# Altium schematic sheet ReboostV2_USB.SchDoc
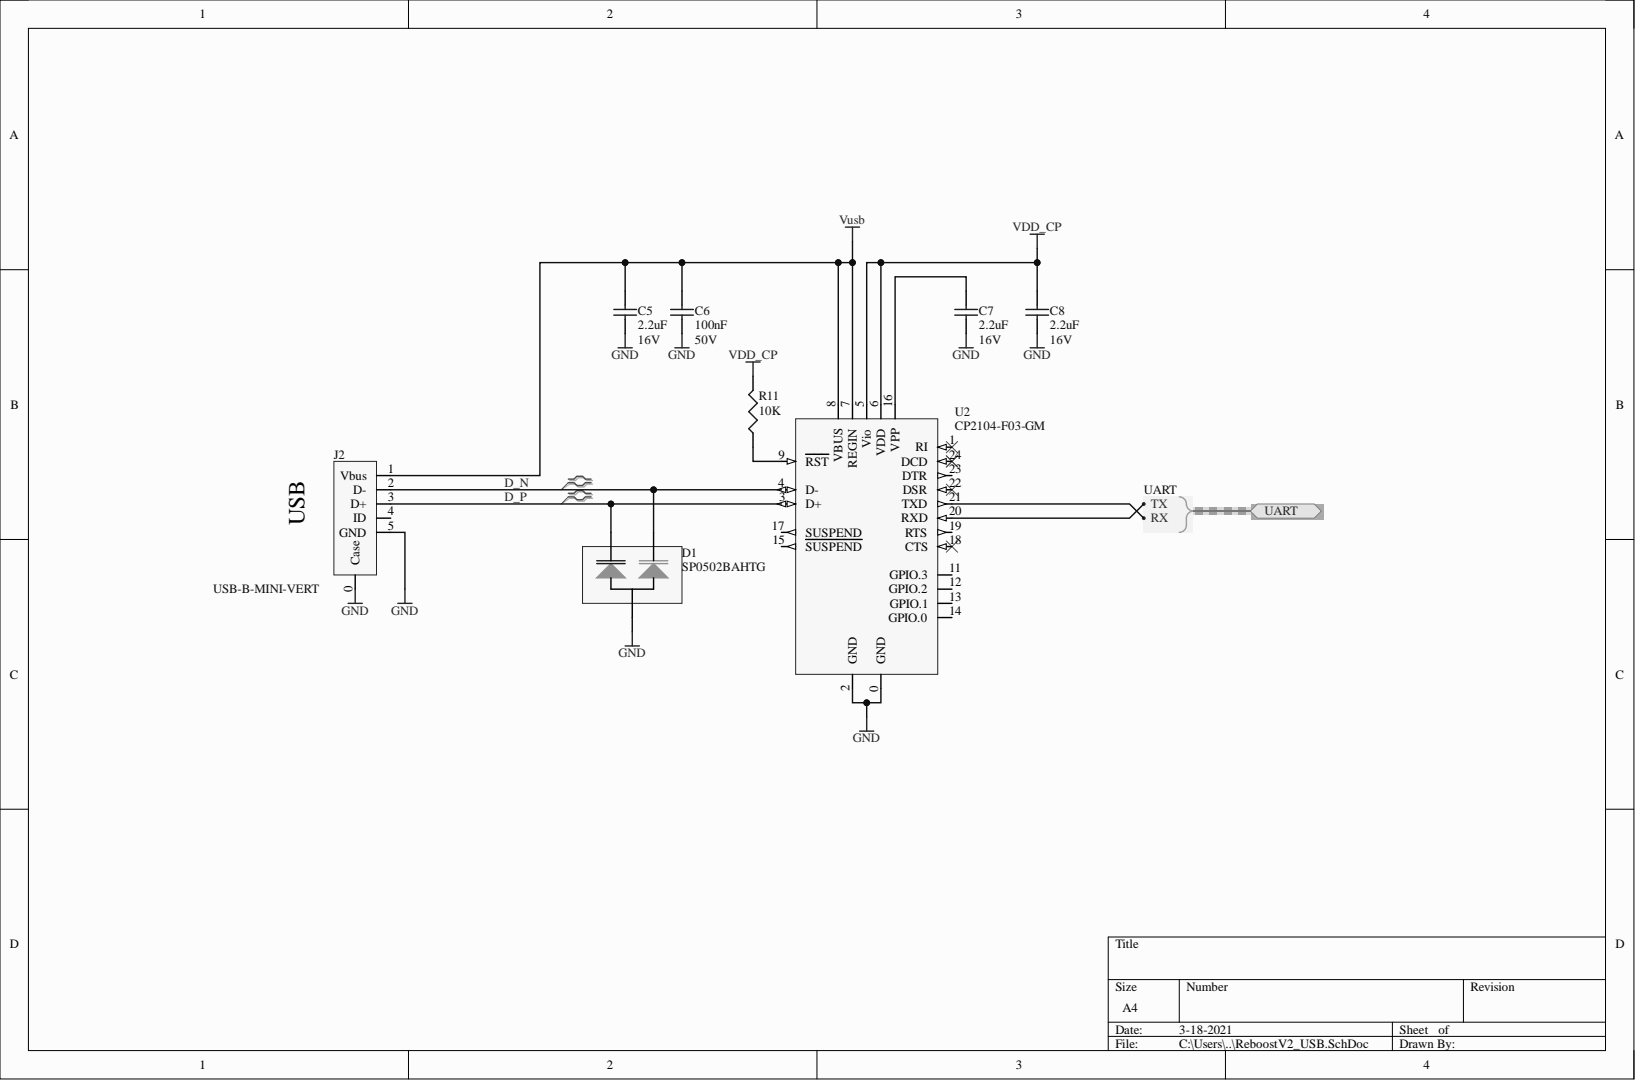
[diagram p0: ReboostV2_USB.SchDoc]

## Parts
C5  2.2uF  Voltage Rating: 16V  [C0603]
C6  100nF  Voltage Rating: 50V  [C0603]
C7  2.2uF  Voltage Rating: 16V  [C0603]
C8  2.2uF  Voltage Rating: 16V  [C0603]
D1  SP0502BAHTG  [SOT23]
J2  USB-B-MINI-VERT  [USB-B-MINI-VERT]
R11  10K  [0603]
U2  CP2104-F03-GM  [QFN24]

## Ports
UART, UART.RX, UART.TX

## Nets
GND: C5.1 C6.1 C7.1 C8.1 D1.A J2.0(Case) J2.5(GND) U2.0(GND) U2.2(GND)
VDD_CP: C8.2 R11.2 U2.5(Vio) U2.6(VDD)
Vusb: C5.2 C6.2 J2.1(Vbus) U2.7(REGIN) U2.8(VBUS)
D_N: D1.K1 J2.2(D-) U2.4(D-)
D_P: D1.K2 J2.3(D+) U2.3(D+)
NetC7_2: C7.2 U2.16(VPP)
NetJ2_4: J2.4(ID)
NetR11_1: R11.1 U2.9(RST)
NetU2_1: U2.1(RI)
NetU2_11: U2.11(GPIO.3)
NetU2_12: U2.12(GPIO.2)
NetU2_13: U2.13(GPIO.1)
NetU2_14: U2.14(GPIO.0)
NetU2_15: U2.15(SUSPEND)
NetU2_17: U2.17(SUSPEND)
NetU2_18: U2.18(CTS)
NetU2_19: U2.19(RTS)
NetU2_20: U2.20(RXD) ; port UART
NetU2_21: U2.21(TXD) ; port UART
NetU2_22: U2.22(DSR)
NetU2_23: U2.23(DTR)
NetU2_24: U2.24(DCD)

## Library data of the parts
C5 C7 C8 = 2.2uF/0603/CER/16V: Capacitor, 2.2uF, 16V, X5R; Brand: MURATA; Brand Number: GRM188R61C225KE15D; Capacitance Tolerance: +/- 10%; Capacitor Case Style: 0603; Capacitance: 2.2uF; Component Kind: Standard; Dielectric Characteristic: X5R; Library Name: AR_Capacitors_Ceramic.IntLib; Order Code Supplier: 1735526; Price: 0,077; Supplier: Farnell; Temperature Range: -55°C to 85°C
C6 = 100nF/0603/CER/50V: Capacitor, 100nF, 50V, X7R; Brand: MULTICOMP; Brand Number: MCCA000255; Capacitance Tolerance: ± 10%; Capacitor Case Style: 0603; Capacitance: 100nF; Component Kind: Standard; Dielectric Characteristic: X7R; Library Name: AR_Capacitors_Ceramic.IntLib; Order Code Supplier: 1759122RL; Price: 0,008; Supplier: Farnell; Temperature Range: -55°C to +125°C
D1 = SP0502BAHTG: Diode TVS Dual, Vrwm: 5.5V Vc: 8V; Brand: LITTLEFUSE; Case Style: SOT-23; Brand Number: SP0502BAHTG; Clamping Voltage: 8V; Component Kind: Standard; Library Name: AR_Diodes.IntLib; Order Code Supplier: 1827631; Price: 0,80; Supplier: Farnell; Temperature Range: -40°C to +125°C; Vrwm: 5.5V
J2 = WE-651005136421: Connector, Usb B Mini, Vertical, TH, WR-COM; Brand: WURTH ELEKTRONIK; Brand Number: 651005136421; Case Style: WR-COM; Component Kind: Standard; Library Name: AR_Connectors.IntLib; Order Code Supplier: 1841175; Price: 3,37; Supplier: Farnell
R11 = 10K/0603/0063W/50V: Resistor, 10K, 1%, 0.063W, 50V, 100PPM, 0603; Brand: VISHAY DRALORIC; Brand Number: CRCW060310K0FKEA; Case Style: 0603; Component Kind: Standard; Library Name: AR_Resistors_SMD.IntLib; Order Code Supplier: 2122493; Power Rating: 0.063W; Price: 0,038; Resistance: 10K; Supplier: Farnell; Temperature Coefficient: ± 100PPM; Temperature Range: -55°C to +125°C; Voltage Rating: 50V
U2 = CP2104-F03-GM: USB to UART converter; Brand: Silicon Labs; Brand Number: CP2104-F03-GM; Case Style: QFN24; Component Kind: Standard; Library Name: AR_ICs.IntLib; Order Code Supplier: 757-4983; Price: 1,65; Supplier: RS-Components
